# Altium schematic sheet DRIVER_FETS_S08.SchDoc
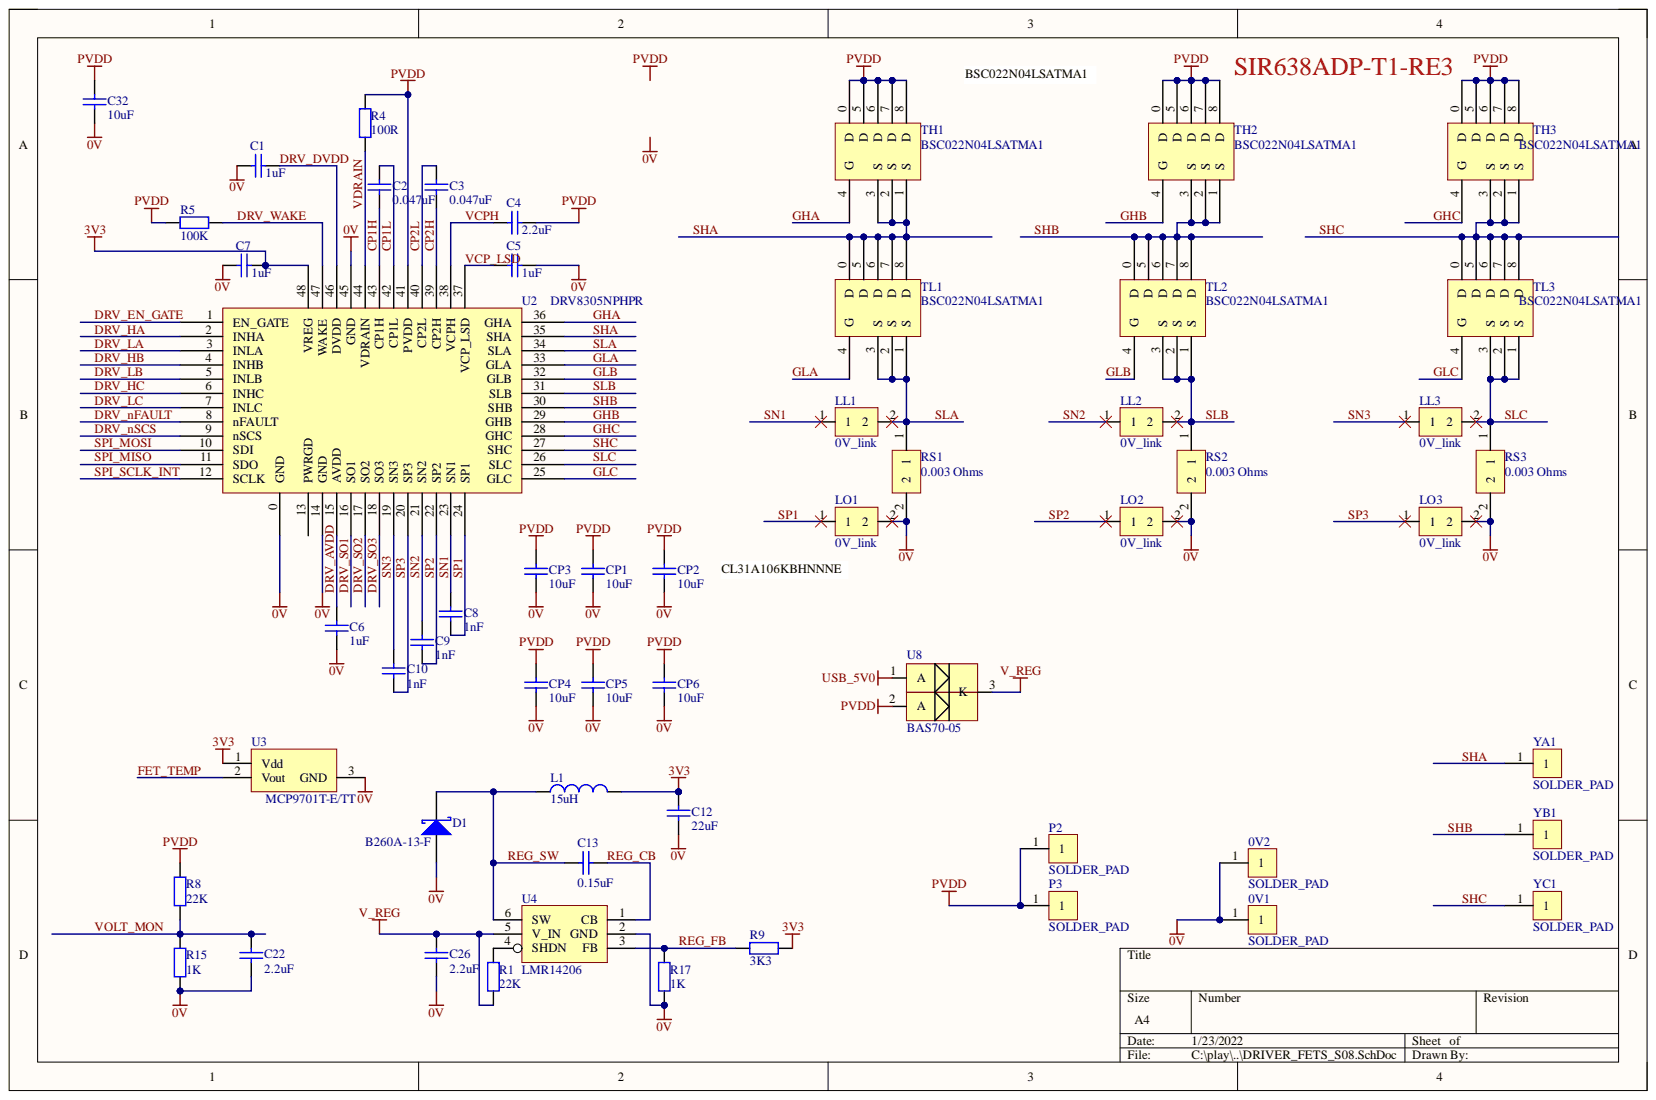
[diagram p0: DRIVER_FETS_S08.SchDoc]

## Parts
0V1  SOLDER_PAD  [SOLDER_PAD_TH]
0V2  SOLDER_PAD  [SOLDER_PAD_TH]
C1  value 1uF  [0402]  (hidden comment: C15849)
C2  value 0.047uF  [0402]  (hidden comment: C1622)
C3  value 0.047uF  [0402]  (hidden comment: C1622)
C4  value 2.2uF  [0603C]  (hidden comment: C50254)
C5  value 1uF  [0603C]  (hidden comment: C15849)
C6  value 1uF  [0402]  (hidden comment: C15849)
C7  value 1uF  [0402]  (hidden comment: C15849)
C8  value 1nF  [0402]  (hidden comment: C1588)
C9  value 1nF  [0402]  (hidden comment: C1588)
C10  value 1nF  [0402]  (hidden comment: C1588)
C12  value 22uF  [0805C]  (hidden comment: C59461)
C13  value 0.15uF  [0603C]
C22  value 2.2uF  [0603C]  (hidden comment: C50254)
C26  value 2.2uF  [0805C]  (hidden comment: C49217)
C32  value 10uF  [1206]  (hidden comment: C13585)
CP1  value 10uF  [1206]  (hidden comment: C13585)
CP2  value 10uF  [1206]  (hidden comment: C13585)
CP3  value 10uF  [1206]  (hidden comment: C13585)
CP4  value 10uF  [1206]  (hidden comment: C13585)
CP5  value 10uF  [1206]  (hidden comment: C13585)
CP6  value 10uF  [1206]  (hidden comment: C13585)
D1  value B260A-13-F  [SOD323]  (hidden comment: C8598)
L1  value 15uH  [VLS3015E]
LL1  0V_link  [0V_link]
LL2  0V_link  [0V_link]
LL3  0V_link  [0V_link]
LO1  0V_link  [0V_link]
LO2  0V_link  [0V_link]
LO3  0V_link  [0V_link]
P2  SOLDER_PAD  [SOLDER_PAD_TH]
P3  SOLDER_PAD  [SOLDER_PAD_TH]
R1  value 22K  [0402R]  (hidden comment: C31850)
R4  value 100R  [0603R]  (hidden comment: C22775)
R5  value 100K  [0402R]  (hidden comment: C25803)
R8  value 22K  [0402]  (hidden comment: C31850)
R9  value 3K3  [0402R]  (hidden comment: C22978)
R15  value 1K  [0402R]  (hidden comment: C21190)
R17  value 1K  [0402R]  (hidden comment: C21190)
RS1  value 0.003 Ohms  [1206R_fat]  (hidden comment: C326608)
RS2  value 0.003 Ohms  [1206R_fat]  (hidden comment: C326608)
RS3  value 0.003 Ohms  [1206R_fat]  (hidden comment: C326608)
TH1  value BSC022N04LSATMA1  [SOP8_ADV]  (hidden comment: C148266)
TH2  value BSC022N04LSATMA1  [SOP8_ADV]  (hidden comment: C148266)
TH3  value BSC022N04LSATMA1  [SOP8_ADV]  (hidden comment: C148266)
TL1  value BSC022N04LSATMA1  [SOP8_ADV]  (hidden comment: C148266)
TL2  value BSC022N04LSATMA1  [SOP8_ADV]  (hidden comment: C148266)
TL3  value BSC022N04LSATMA1  [SOP8_ADV]  (hidden comment: C148266)
U2  value DRV8305NPHPR  [HTQFP-48]  (hidden comment: C75602)
U3  value MCP9701T-E/TT  [SOT-23]  (hidden comment: C129485)
U4  value LMR14206  [SOP6]  (hidden comment: C48239)
U8  value BAS70-05  [SOT-23]  (hidden comment: C134376)
YA1  SOLDER_PAD  [SOLDER_PAD_TH]
YB1  SOLDER_PAD  [SOLDER_PAD_TH]
YC1  SOLDER_PAD  [SOLDER_PAD_TH]

## Nets
0V: 0V1.1 0V2.1 C1.1 C5.2 C6.1 C7.1 C12.1 C22.1 C26.1 C32.1 CP1.1 CP2.1 CP3.1 CP4.1 CP5.1 CP6.1 D1.1 LO1.2 LO2.2 LO3.2 R15.2 R17.2 RS1.2 RS2.2 RS3.2 U2.0(GND) U2.14(GND) U2.45(GND) U3.3 U4.2(GND)
3V3: C7.2 C12.2 L1.2 R9.2 U2.48(VREG) U3.1
PVDD: C4.2 C32.2 CP1.2 CP2.2 CP3.2 CP4.2 CP5.2 CP6.2 P2.1 P3.1 R4.1 R5.1 R8.2 TH1.0(D) TH1.5(D) TH1.6(D) TH1.7(D) TH1.8(D) TH2.0(D) TH2.5(D) TH2.6(D) TH2.7(D) TH2.8(D) TH3.0(D) TH3.5(D) TH3.6(D) TH3.7(D) TH3.8(D) U2.41(PVDD) U8.2
USB_5V0: U8.1
V_REG: C26.2 R1.1 U4.5(V_IN) U8.3
CP1H: C2.1 U2.43(CP1H)
CP1L: C2.2 U2.42(CP1L)
CP2H: C3.1 U2.39(CP2H)
CP2L: C3.2 U2.40(CP2L)
DRV_AVDD: C6.2 U2.15(AVDD)
DRV_DVDD: C1.2 U2.46(DVDD)
DRV_EN_GATE: U2.1(EN_GATE)
DRV_HA: U2.2(INHA)
DRV_HB: U2.4(INHB)
DRV_HC: U2.6(INHC)
DRV_LA: U2.3(INLA)
DRV_LB: U2.5(INLB)
DRV_LC: U2.7(INLC)
DRV_nFAULT: U2.8(nFAULT)
DRV_nSCS: U2.9(nSCS)
DRV_SO1: U2.16(SO1)
DRV_SO2: U2.17(SO2)
DRV_SO3: U2.18(SO3)
DRV_WAKE: R5.2 U2.47(WAKE)
FET_TEMP: U3.2
GHA: TH1.4(G) U2.36(GHA)
GHB: TH2.4(G) U2.29(GHB)
GHC: TH3.4(G) U2.28(GHC)
GLA: TL1.4(G) U2.33(GLA)
GLB: TL2.4(G) U2.32(GLB)
GLC: TL3.4(G) U2.25(GLC)
REG_CB: C13.1 U4.1(CB)
REG_FB: R9.1 R17.1 U4.3(FB)
REG_SW: C13.2 D1.2 L1.1 U4.6(SW)
SHA: TH1.1(S) TH1.2(S) TH1.3(S) TL1.0(D) TL1.5(D) TL1.6(D) TL1.7(D) TL1.8(D) U2.35(SHA) YA1.1
SHB: TH2.1(S) TH2.2(S) TH2.3(S) TL2.0(D) TL2.5(D) TL2.6(D) TL2.7(D) TL2.8(D) U2.30(SHB) YB1.1
SHC: TH3.1(S) TH3.2(S) TH3.3(S) TL3.0(D) TL3.5(D) TL3.6(D) TL3.7(D) TL3.8(D) U2.27(SHC) YC1.1
SLA: LL1.2 RS1.1 TL1.1(S) TL1.2(S) TL1.3(S) U2.34(SLA)
SLB: LL2.2 RS2.1 TL2.1(S) TL2.2(S) TL2.3(S) U2.31(SLB)
SLC: LL3.2 RS3.1 TL3.1(S) TL3.2(S) TL3.3(S) U2.26(SLC)
SN1: C8.2 LL1.1 U2.23(SN1)
SN2: C9.2 LL2.1 U2.21(SN2)
SN3: C10.2 LL3.1 U2.19(SN3)
SP1: C8.1 LO1.1 U2.24(SP1)
SP2: C9.1 LO2.1 U2.22(SP2)
SP3: C10.1 LO3.1 U2.20(SP3)
SPI_MISO: U2.11(SDO)
SPI_MOSI: U2.10(SDI)
SPI_SCLK_INT: U2.12(SCLK)
VCP_LSD: C5.1 U2.37(VCP_LSD)
VCPH: C4.1 U2.38(VCPH)
VDRAIN: R4.2 U2.44(VDRAIN)
VOLT_MON: C22.2 R8.1 R15.1
NetR1_2: R1.2 U4.4(SHDN)

Not connected: U2 13(PWRGD)

## Library data of the parts
C1-C10 C12 C13 C22 C26 C32 CP1-CP6 = Cap: Capacitor; Component Kind: Standard; LatestRevisionDate: 17-Jul-2002; LatestRevisionNote: Re-released for DXP Platform.; Library Name: Miscellaneous Devices.IntLib; PackageDescription: Radial Cap, Thru-Hole; 2 Leads; 0.3 in Pin Spacing; PackageReference: RAD-0.3; Published: 8-Jun-2000; Publisher: Altium Limited
D1 = D Schottky: Schottky Diode; Code_JEDEC: DO-214-AA; Component Kind: Standard; LatestRevisionDate: 17-Jul-2002; LatestRevisionNote: Re-released for DXP Platform.; Library Name: Miscellaneous Devices.IntLib; PackageDescription: DO-214-AA/SMB; 2 C-Bend Leads; Body 5.3 x 3.6 mm, inc. leads (LxW); PackageReference: SMB; Published: 8-Jun-2000; Publisher: Altium Limited
L1 = Inductor: Code_IEC: 1005; Code_JEITA: 0402; Component Kind: Standard; Description: Inductor; LatestRevisionDate: 29-May-2009; LatestRevisionNote: IPC-7351 Footprint Added.; Library Name: Miscellaneous Devices.IntLib; PackageDescription: Chip Inductor; PackageReference: 0402-A; Published: 8-Jun-2000; Publisher: Altium Limited
R1 R4 R5 R8 R9 R15 R17 = Res2: Resistor; Component Kind: Standard; LatestRevisionDate: 17-Jul-2002; LatestRevisionNote: Re-released for DXP Platform.; Library Name: Miscellaneous Devices.IntLib; PackageDescription: Axial Device, Thru-Hole; 2 Leads; 0.4 in Pin Spacing; PackageReference: AXIAL-0.4; Published: 8-Jun-2000; Publisher: Altium Limited
RS1-RS3 = R_SEN: Component Kind: Standard; Library Name: BLDC_LIB.SCHLIB
TH1-TH3 TL1-TL3 = TPH2R608NH: Component Kind: Standard; Library Name: Brushless PSOC driver.SCHLIB
U2 = DRV8305: Component Kind: Standard; Library Name: Brushless PSOC driver.SCHLIB
U3 = MCP9701T-E/TT: Component Kind: Standard; Library Name: Brushless PSOC driver.SCHLIB
U4 = LMR14203: Component Kind: Standard; Library Name: Brushless PSOC driver.SCHLIB
U8 = BAV70: Component Kind: Standard; Library Name: BLDC_LIB.SCHLIB
0V1 0V2 P2 P3 YA1 YB1 YC1 = SOLDER_PAD: Component Kind: Standard; Library Name: Brushless PSOC driver.SCHLIB
LL1-LL3 LO1-LO3 = 0V_link: Component Kind: Standard; Library Name: Brushless PSOC driver.SCHLIB
